# Altium schematic sheet P01 DP TO  HDMI.SchDoc("P01 DP TO  HDMI")
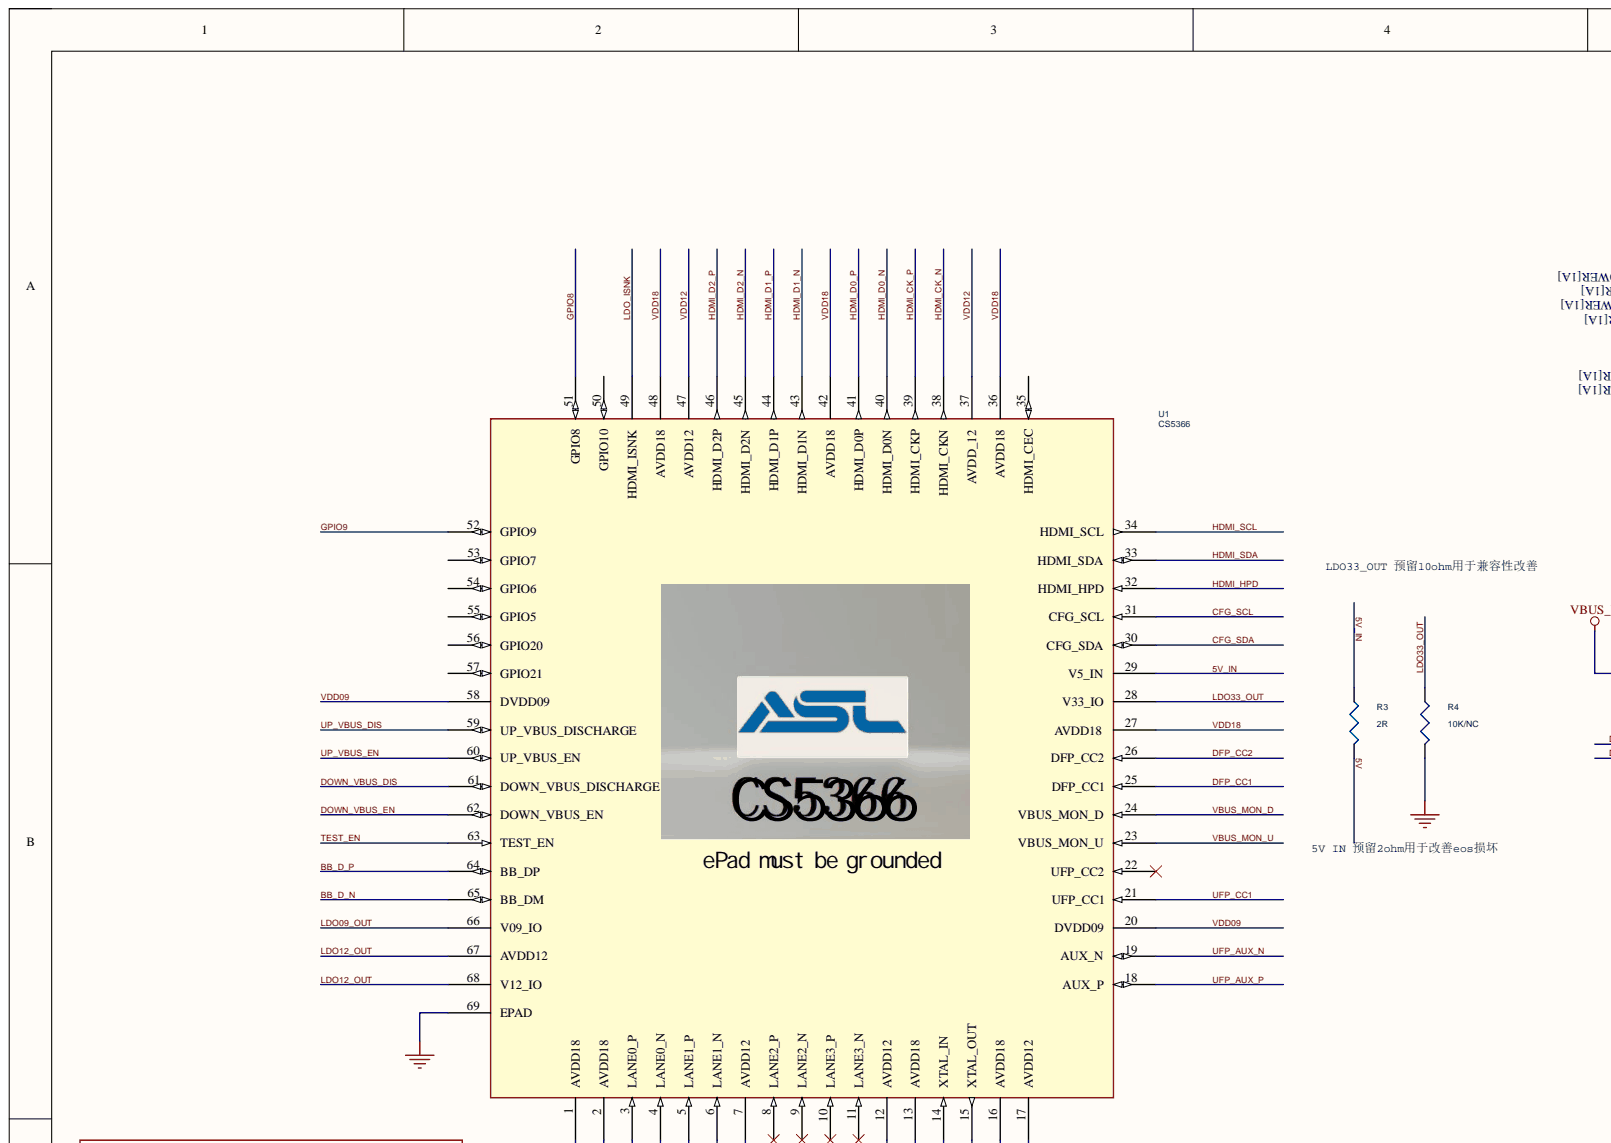
[diagram p0: P01 DP TO  HDMI.SchDoc, top left]
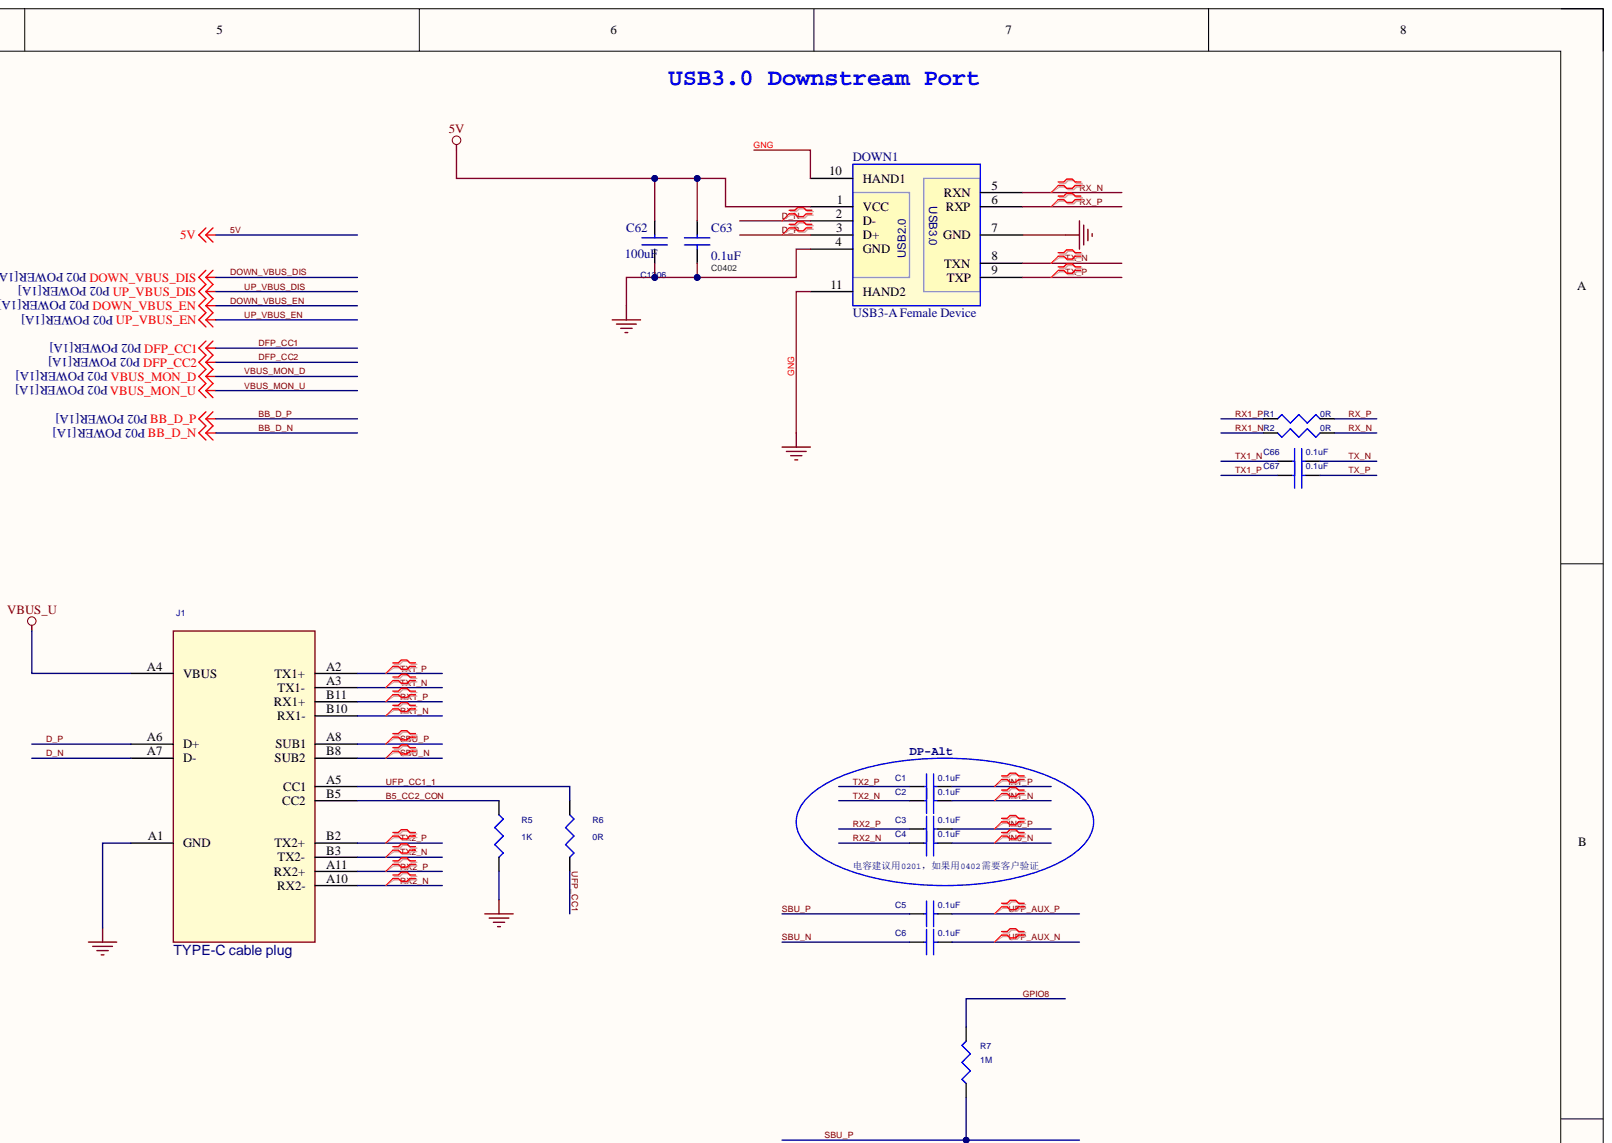
[diagram p1: P01 DP TO  HDMI.SchDoc, top right]
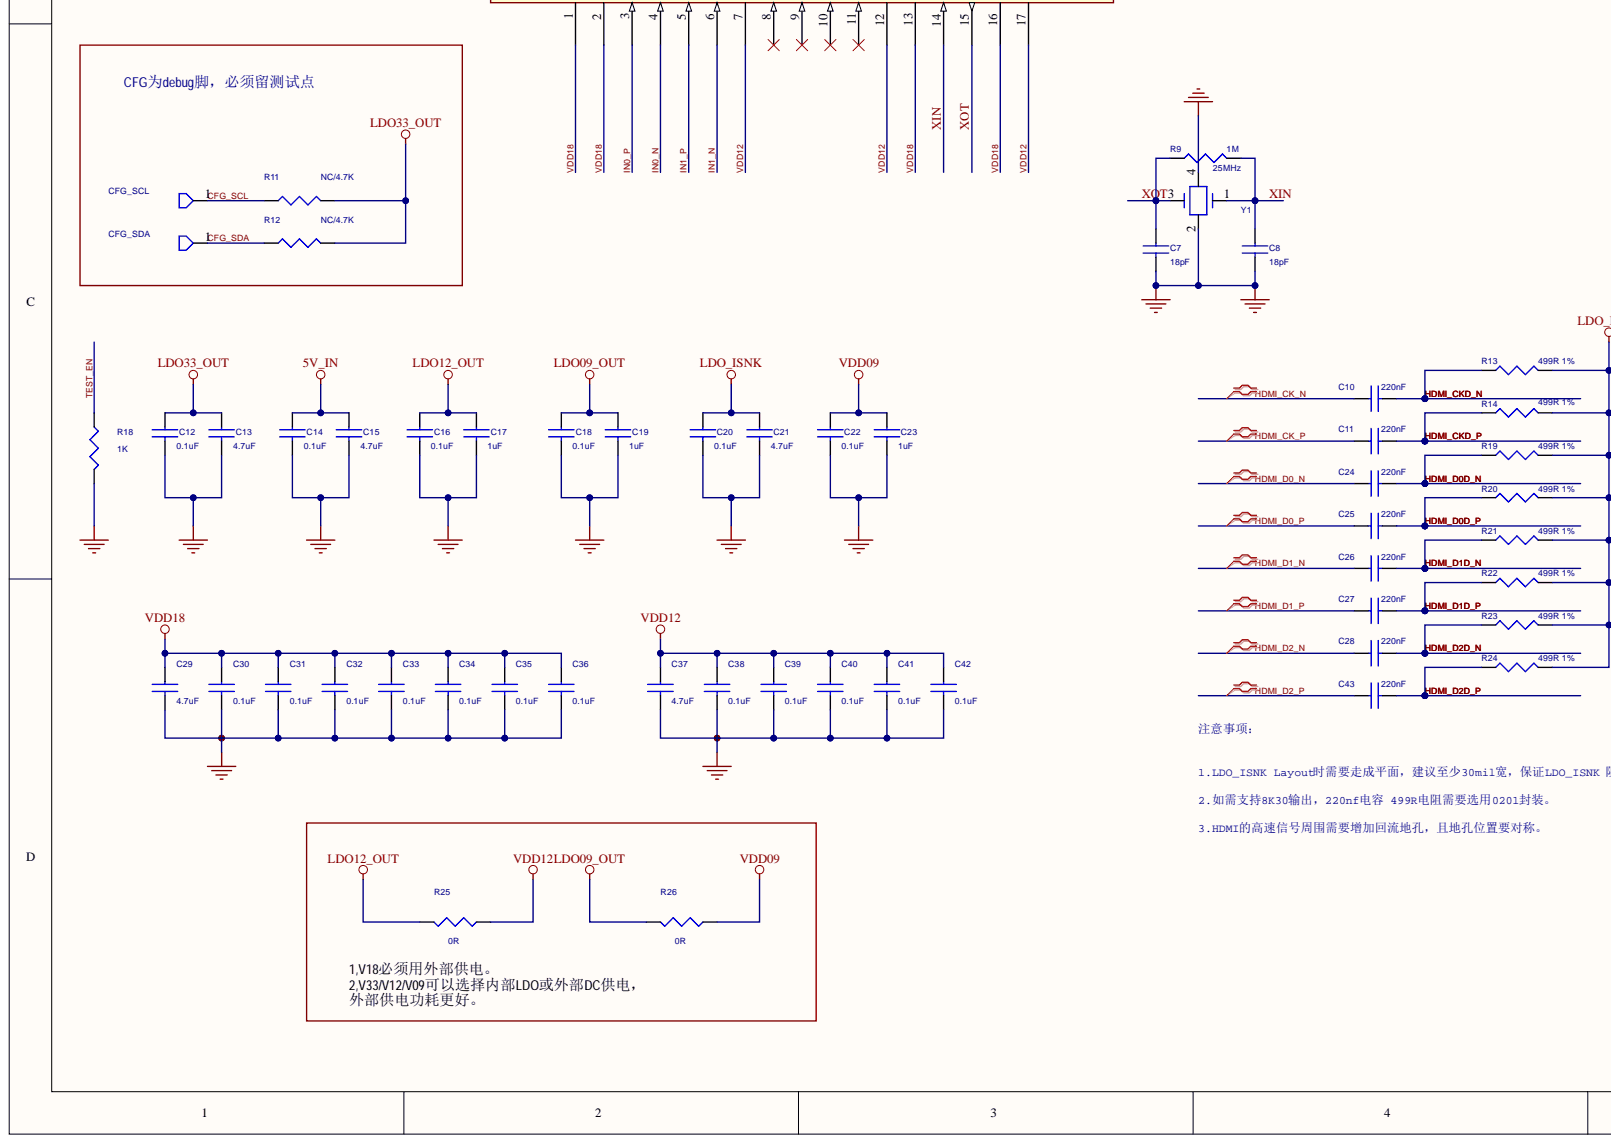
[diagram p2: P01 DP TO  HDMI.SchDoc, bottom left]
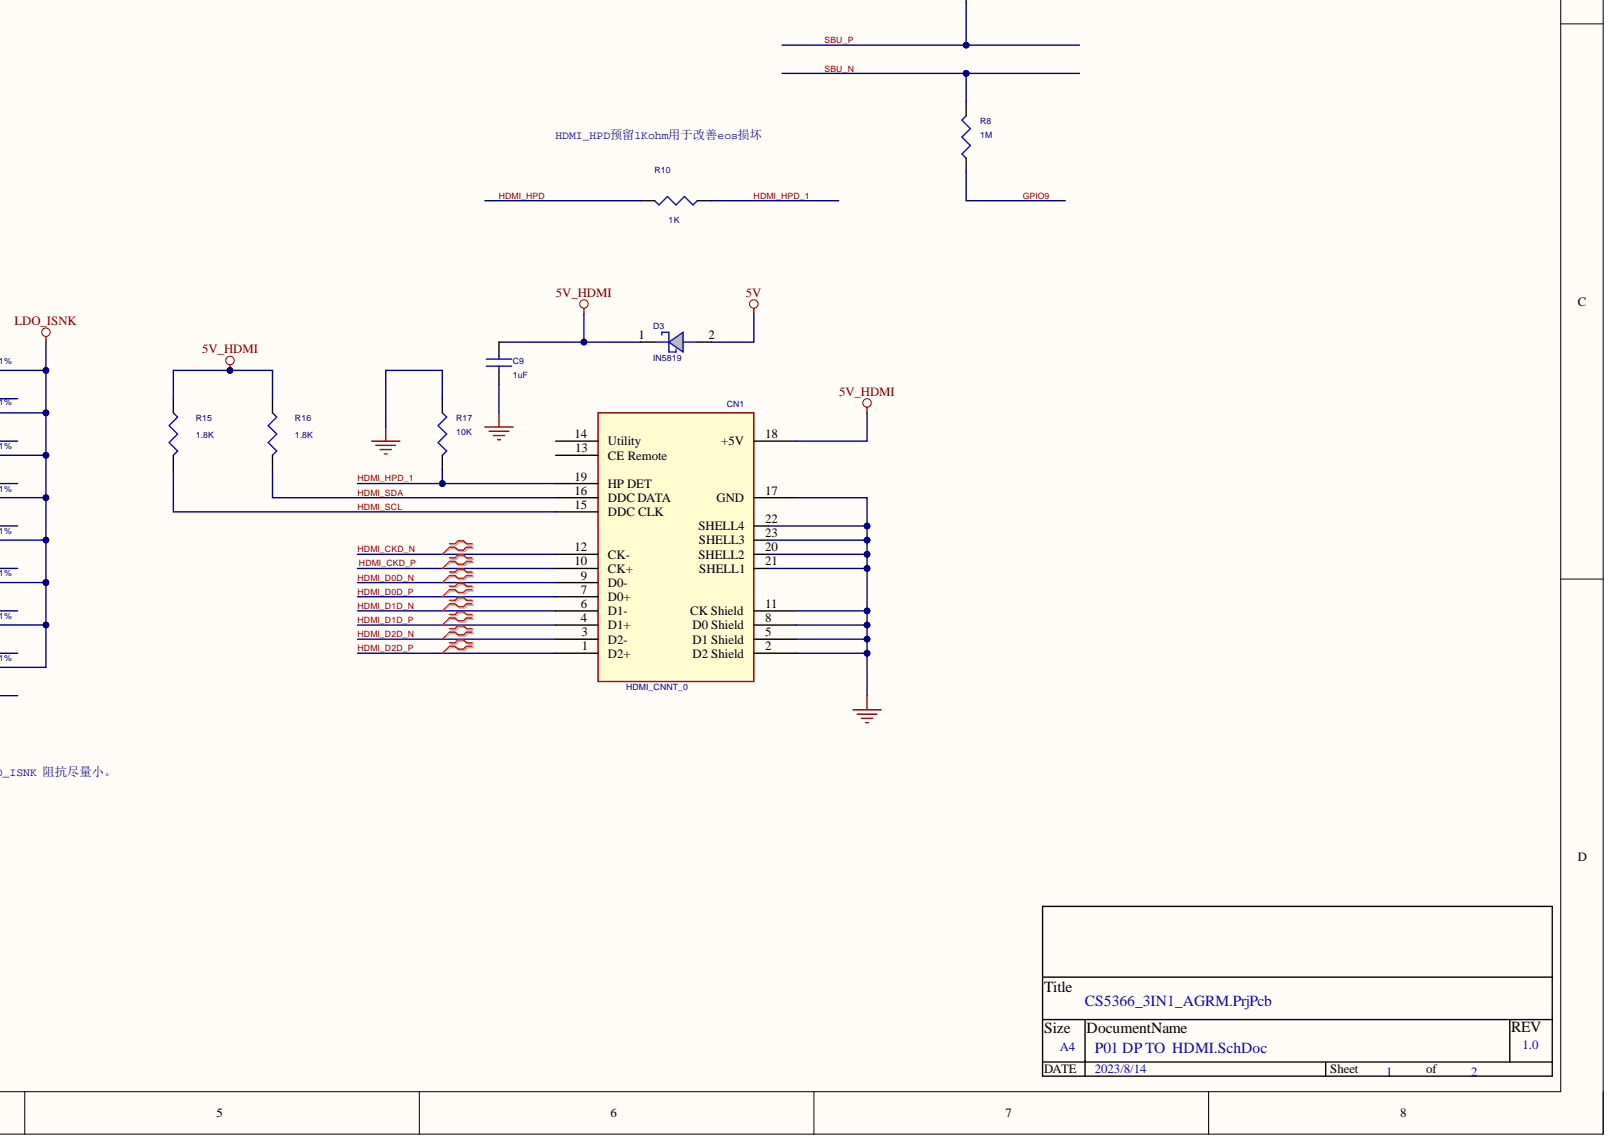
[diagram p3: P01 DP TO  HDMI.SchDoc, bottom right]

## Parts
C1  0.1uF  [C0402]
C2  0.1uF  [C0402]
C3  0.1uF  [C0402]
C4  0.1uF  [C0402]
C5  0.1uF  [C0402]
C6  0.1uF  [C0402]
C7  18pF  [c0402]
C8  18pF  [c0402]
C9  1uF  [C0402]
C10  220nF  [C0201]
C11  220nF  [C0201]
C12  0.1uF  [C0402]
C13  4.7uF  [C0603]
C14  0.1uF  [C0402]
C15  4.7uF  [C0603]
C16  0.1uF  [C0402]
C17  1uF  [C0402]
C18  0.1uF  [C0402]
C19  1uF  [C0402]
C20  0.1uF  [C0402]
C21  4.7uF  [C0603]
C22  0.1uF  [C0402]
C23  1uF  [C0402]
C24  220nF  [C0201]
C25  220nF  [C0201]
C26  220nF  [C0201]
C27  220nF  [C0201]
C28  220nF  [C0201]
C29  4.7uF  [C0603]
C30  0.1uF  [C0402]
C31  0.1uF  [C0402]
C32  0.1uF  [C0402]
C33  0.1uF  [C0402]
C34  0.1uF  [C0402]
C35  0.1uF  [C0402]
C36  0.1uF  [C0402]
C37  4.7uF  [C0603]
C38  0.1uF  [C0402]
C39  0.1uF  [C0402]
C40  0.1uF  [C0402]
C41  0.1uF  [C0402]
C42  0.1uF  [C0402]
C43  220nF  [C0201]
C44  CFG_SCL  [TP]
C62  100uF  PCB Footprint: C1206  [C0603]
C63  0.1uF  [C0402]
C66  0.1uF  [C0402]
C67  0.1uF  [C0402]
CN1  HDMI_CNNT_0  [HDMI_-A]
D3  IN5819  [SOD323]
D6  CFG_SDA  [TP]
DOWN1  USB3-A Female Device  [USB3.0_AF_SMT-A]
J1  TYPE-C cable plug  [TYPEC-XJ-17P]
R1  0R  [R0402]
R2  0R  [R0402]
R3  2R  [R0603]
R4  10K/NC  [R0402]
R5  1K  [R0402]
R6  0R  [R0402]
R7  1M  [R0402]
R8  1M  [R0402]
R9  1M  [R0402]
R10  1K  [R0402]
R11  NC/4.7K  [R0402]
R12  NC/4.7K  [R0402]
R13  499R 1%  [R0201]
R14  499R 1%  [R0201]
R15  1.8K  [R0402]
R16  1.8K  [R0402]
R17  10K  [R0402]
R18  1K  [R0402]
R19  499R 1%  [R0201]
R20  499R 1%  [R0201]
R21  499R 1%  [R0201]
R22  499R 1%  [R0201]
R23  499R 1%  [R0201]
R24  499R 1%  [R0201]
R25  0R  [R0603]
R26  0R  [R0603]
U1  CS5366  [QFN68]
Y1  25MHz  [SMT3225v]

## Nets
5V_HDMI: C9.1 CN1.18 D3.1 R15.2 R16.2
VBUS_U: J1.A4
5V: C62.2 C63.1 D3.2 DOWN1.1 R3.1
5V_IN: C14.1 C15.1 R3.2 U1.29
B5_CC2_CON: J1.B5 R5.2
BB_D_N: U1.65
BB_D_P: U1.64
CFG_SCL: C44.1 R11.2 U1.31
CFG_SDA: D6.1 R12.2 U1.30
D_N: DOWN1.2 J1.A7
D_P: DOWN1.3 J1.A6
DFP_CC1: U1.25
DFP_CC2: U1.26
DOWN_VBUS_DIS: U1.61
DOWN_VBUS_EN: U1.62
GNG: C7.2 C8.2 C9.2 C12.2 C13.2 C14.2 C15.2 C16.2 C17.2 C18.2 C19.2 C20.2 C21.2 C22.2 C23.2 C29.2 C30.2 C31.2 C32.2 C33.2 C34.2 C35.2 C36.2 C37.2 C38.2 C39.2 C40.2 C41.2 C42.2 C62.1 C63.2 CN1.2 CN1.5 CN1.8 CN1.11 CN1.17 CN1.20 CN1.21 CN1.22 CN1.23 DOWN1.4 DOWN1.7 DOWN1.10 DOWN1.11 J1.A1 R4.1 R5.1 R17.2 R18.1 U1.69 Y1.2 Y1.4
GPIO8: R7.1 U1.51
GPIO9: R8.2 U1.52
HDMI_CK_N: C10.1 U1.38
HDMI_CK_P: C11.1 U1.39
HDMI_CKD_N: C10.2 CN1.12 R13.1
HDMI_CKD_P: C11.2 CN1.10 R14.1
HDMI_D0_N: C24.1 U1.40
HDMI_D0_P: C25.1 U1.41
HDMI_D0D_N: C24.2 CN1.9 R19.1
HDMI_D0D_P: C25.2 CN1.7 R20.1
HDMI_D1_N: C26.1 U1.43
HDMI_D1_P: C27.1 U1.44
HDMI_D1D_N: C26.2 CN1.6 R21.1
HDMI_D1D_P: C27.2 CN1.4 R22.1
HDMI_D2_N: C28.1 U1.45
HDMI_D2_P: C43.1 U1.46
HDMI_D2D_N: C28.2 CN1.3 R23.1
HDMI_D2D_P: C43.2 CN1.1 R24.1
HDMI_HPD: R10.1 U1.32
HDMI_HPD_1: CN1.19 R10.2 R17.1
HDMI_SCL: CN1.15 R15.1 U1.34
HDMI_SDA: CN1.16 R16.1 U1.33
IN0_N: C4.2 U1.4
IN0_P: C3.2 U1.3
IN1_N: C2.2 U1.6
IN1_P: C1.2 U1.5
LDO09_OUT: C18.1 C19.1 R26.1 U1.66
LDO12_OUT: C16.1 C17.1 R25.1 U1.67 U1.68
LDO33_OUT: C12.1 C13.1 R4.2 R11.1 R12.1 U1.28
LDO_ISNK: C20.1 C21.1 R13.2 R14.2 R19.2 R20.2 R21.2 R22.2 R23.2 R24.2 U1.49
RX1_N: J1.B10 R2.1
RX1_P: J1.B11 R1.2
RX2_N: C4.1 J1.A10
RX2_P: C3.1 J1.A11
RX_N: DOWN1.5 R2.2
RX_P: DOWN1.6 R1.1
SBU_N: C6.1 J1.B8 R8.1
SBU_P: C5.1 J1.A8 R7.2
TEST_EN: R18.2 U1.63
TX1_N: C66.1 J1.A3
TX1_P: C67.1 J1.A2
TX2_N: C2.1 J1.B3
TX2_P: C1.1 J1.B2
TX_N: C66.2 DOWN1.8
TX_P: C67.2 DOWN1.9
UFP_AUX_N: C6.2 U1.19
UFP_AUX_P: C5.2 U1.18
UFP_CC1: R6.1 U1.21
UFP_CC1_1: J1.A5 R6.2
UP_VBUS_DIS: U1.59
UP_VBUS_EN: U1.60
VBUS_MON_D: U1.24
VBUS_MON_U: U1.23
VDD09: C22.1 C23.1 R26.2 U1.20 U1.58
VDD12: C37.1 C38.1 C39.1 C40.1 C41.1 C42.1 R25.2 U1.7 U1.12 U1.17 U1.37 U1.47
VDD18: C29.1 C30.1 C31.1 C32.1 C33.1 C34.1 C35.1 C36.1 U1.1 U1.2 U1.13 U1.16 U1.27 U1.36 U1.42 U1.48
XIN: C8.1 R9.2 U1.14 Y1.1
XOT: C7.1 R9.1 U1.15 Y1.3

Not connected: CN1 13 14; U1 8 9 10 11 22 35 50 53 54 55 56 57

## Library data of the parts
C1-C6 C66 C67 = CAP NP_1: Component Kind: Standard
C7 C8 C10 C11 C24-C28 C43 = CAP NP_Dup1: Component Kind: Standard
C9 C12-C23 C29-C42 = CAP 0603_5: Component Kind: Standard
C44 D6 = T POINT F: Component Kind: Standard
C62 = Cap 0603 10uf 6.3V Ceramic: 0_MANUFACTURER: Taiyo Yuden; 0_PARTNUM: EMK107BJ105KA-TR; Component Kind: Standard
C63 = CAP 0402_1: Component Kind: Standard; PCB Footprint: C0402
CN1 = HDMI_CNNT_5: HDMI_SMT_1.2A; MFG: JAE; P/N: 11.190M4G007; PN0: 11.190M4G002; PN0D: CNNT HDMI M 19P 0.5mm SMT/RT 47151-1101; "MOLEX; Component Kind: Standard; Vendor: JAE
D3 = DIODE SCHOTTKY_2: Location: T; P/N: 09.PMEG4G001; Component Kind: Standard; Library Name: CS5366_XW1211_AGRM.SCHLIB
DOWN1 = USB3-A_0: PCB Footprint: USB3.0AF/H2.45; Component Kind: Standard
J1 = TYPE-C cable plug: BOM_Manufacturer: SHOU HAN; BOM_Manufacturer Part: TYPE-C 24P QCHT; Contributor: LCSC; BOM_Supplier: LCSC; BOM_Supplier Part: C456013; Component Kind: Standard; spicePre: U; spiceSymbolName: TYPE-C 24P QCHT
R1-R8 R10-R12 R15-R18 R25 R26 = R-0603_12: Component Kind: Standard
R9 R13 R14 R19-R24 = R0603: 0_MANUFACTURER: Panasonic; 0_PARTNUM: ERJ-3GEYJ105V; Component Kind: Standard
U1 = CS536X_BA_1: Component Kind: Standard; Library Name: CS5366_XW1211_AGRM.SCHLIB
Y1 = CRYSTAL_SMD: Component Kind: Standard
